# Altium schematic sheet WiFi_Portable_Sensor_Board_C0_MCU-PWR-UI_20180525.SchDoc (continued)
## Library data of the parts (continued)
TP0 = MISC0025: Manufacturer 1: Keystone; Manufacturer Part Number 1: 5011; Database Table Name: Connector; Description_: CON TP LOOP Black TH; Dev Tools Status: Production; Owner: Tad Ahlvers; Populated: YES; Category: Connector; Component Kind: Standard; ComponentLink1Description: SVN Datasheet; ComponentLink1URL: https://chn-vm-apps.microchip.com/mchprepo/parts/Datasheets/M60-2p60.pdf; ComponentLink2Description: Local Datasheet; ComponentLink3Description: Open Symbol; ComponentLink4Description: Open Footprint; ComponentLink5Description: Open Footprint 2; ComponentLink6Description: Open Footprint 3; ComponentLink7Description: Open Footprint 4; Description: Test Point; Library Name: MCHP-CDB.DbLib; MCHP-ID: MISC0025; Quantity Override: 1; Revision: 0; Status: MCL Design; Supplier 1: Digi-Key; Supplier Part Number 1: 36-5011-ND; Symbol: Test Point; Type: Test Point; ComponentLink2URL: C:\ALTIUM_WORK\00001_MCHP-CDB\Datasheets\M60-2p60.pdf; ComponentLink3URL: C:\ALTIUM_WORK\00001_MCHP-CDB\Library\LibraryRefs\Test Point.SchLib; ComponentLink4URL: C:\ALTIUM_WORK\00001_MCHP-CDB\Library\Footprints\CON_TP_TH_V_BLK_KEC_5011.PcbLib
U1 = MIC3182: MCP738XX; Manufacturer 1: Microchip; AutomaticRecharge: Yes; Database Table Name: MCHP-Analog; Description_: MCHP ANALOG BATTERY CHARGER MCP73833-NVI/UN MSOP-10; Dev Tools Status: Production; ForceMinOrderQtyMult: True; IoutMax: 1200; LeadContent: Pb-FREE; LeadCount: 10; MAPS Description: 1A Advanced Single Cell, Fully Integrated Li-Ion/Polymer Charge Management Contr; MinOrderQty: 50; MPC: DECJ2TE3XANV; NumberofLiIonCells: 1; OperatingCurrentTyp: 2; PackageWidth: 3x3mm; PackingMedia: T/R; PassTransistor: INT; ReverseDischargeCurrentTyp: 0.200000002980232; Stage: REL; tblDeviceFamilyID: 1704; TempRange: -40C to +85C; UVLO: 3.5; VccRangeMax: 6; VccRangeMin: 3.75; VolRegAccuracy: 0.75; VoltageRegulationTyp: 4.2, 4.35, 4.4, 4.5; Category: MCHP-Analog; ChargeMonitor: Yes; ChargeSafetyTimers: Yes; ChargeTermination: Yes; Component Kind: Standard; ComponentLink1Description: SVN Datasheet; ComponentLink1URL: http://www.microchip.com/wwwproducts/Devices.aspx?product=MCP73833; ComponentLink2Description: Local Datasheet; ComponentLink3Description: Open Symbol; ComponentLink4Description: Open Footprint; ComponentLink5Description: Open Footprint 2; ComponentLink6Description: Open Footprint 3; ComponentLink7Description: Open Footprint 4; IntroductionDate: 2006-09-05; Library Name: MCHP-CDB.DbLib; Manufacturer 2: Microchip; Manufacturer Part Number 2: MCP73833T-FCI/UN; MaxThermalRegulation: Yes; MCHP-ID: MIC3182; PackageType: MSOP; Populated: Yes; PreconditionCharge: Yes; Quantity Override: 1; Revision: 0; ShutdownMode: Yes; Status: MCL Design; StatusOutput: Yes; Supplier 1: Microchip; Supplier 2: Microchip; Supplier Part Number 1: MCP73833T-NVI/UN; Supplier Part Number 2: MCP73833-NVI/UN; SupplyPresent: Yes; Symbol: MCP73833_4 MSOP-10; Technology: Linear; TempMonitor: Yes; Type: Battery Charger; ComponentLink3URL: C:\ALTIUM_WORK\00001_MCHP-CDB\Library\LibraryRefs\MCP73833_4 MSOP-10.SchLib; ComponentLink4URL: C:\ALTIUM_WORK\00001_MCHP-CDB\Library\Footprints\MSOP-10.PcbLib
U2 = MIC7215: Manufacturer 1: Microchip Technology; Manufacturer Part Number 1: MIC5317-3.3YD5-T5; Database Table Name: MCHP-Analog; Description_: MCHP ANALOG LDO 3.3V MIC5317-3.3YD5 TSOT23-5; Dev Tools Status: Design; ForceMinOrderQtyMult: True; LeadContent: Pb-FREE; LeadCount: 5; MAPS Description: Single, High Performance 150mA LDO; MinOrderQty: 500; MPC: 22660YD5AA15; Owner: Gabor Bihari; PackingMedia: T/R; Populated: YES; Stage: REL; tblDeviceFamilyID: 7461; TempRange: -40C to +125C; Category: MCHP-Analog; Component Kind: Standard; ComponentLink1Description: SVN Datasheet; ComponentLink1URL: http://www.microchip.com/wwwproducts/Devices.aspx?product=MIC5317; ComponentLink2Description: Local Datasheet; ComponentLink3Description: Open Symbol; ComponentLink4Description: Open Footprint; ComponentLink5Description: Open Footprint 2; ComponentLink6Description: Open Footprint 3; ComponentLink7Description: Open Footprint 4; IntroductionDate: 2015-10-18; Library Name: MCHP-CDB.DbLib; MCHP-ID: MIC7215; PackageType: TSOT; PackageWidth: -; Quantity Override: 1; Revision: A; Status: MCL Design; Supplier 1: Digi-Key; Supplier Part Number 1: 1611-MIC5317-3.3YD5-T5CT-ND; Symbol: MIC5317 FIX TSOT-23-5; Type: LDO; ComponentLink2URL: C:\ALTIUM_WORK\00001_MCHP-CDB\Datasheets\MIC5317.pdf; ComponentLink3URL: C:\ALTIUM_WORK\00001_MCHP-CDB\Library\LibraryRefs\MIC5317 FIX TSOT-23-5.SchLib; ComponentLink4URL: C:\ALTIUM_WORK\00001_MCHP-CDB\Library\Footprints\TSOT-23-5.PcbLib
U3 = MIC7211: Manufacturer 1: Microchip Technology; Manufacturer Part Number 1: ATSAML21G18B-MUT; ADCBit: 12; ADC Channels: 14; CAN: 0; CapTouchCH: 96; Comparators: 2; Database Table Name: MCHP-Microcontroller; Description_: MCHP MCU 32-BIT 48MHz 256kB 32kB ATSAML21G18B-MU QFN-48; Dev Tools Status: Design; DigitalTimerQty_8bit: 5; ForceMinOrderQtyMult: True; I2C: 6; Input Capture: 8; IOPins: 37; LeadContent: Pb-FREE; LeadCount: 48; MAPS Description: 48QFN 85C, GREEN,1.6-3.6V,48MHz; MinOrderQty: 4000; MPC: 661A4TT3BC07; OscillatorMHz: 32Khz ULP, 16Mhz; Owner: Gabor Bihari; PackageWidth: 7x7x1mm; PackingMedia: T/R; Populated: YES; Program Memory KBytes: 256; Program Memory KWords: 64; PWM Channels: 20; Ram: 40960; Stage: REL; TempRange: -40C to +85C; USBChannels: 1; Category: MCHP-Microcontroller; Component Kind: Standard; ComponentLink1Description: SVN Datasheet; ComponentLink1URL: http://www.microchip.com/wwwproducts/Devices.aspx?product=ATSAML21G18B; ComponentLink2Description: Local Datasheet; ComponentLink3Description: Open Symbol; ComponentLink4Description: Open Footprint; ComponentLink5Description: Open Footprint 2; ComponentLink6Description: Open Footprint 3; ComponentLink7Description: Open Footprint 4; DAChannels: 2; DigitalTimerQty_16bit: 5; DigitalTimerQty_32bit: 2; EEPROM: 0; Family: SAM L; IntroductionDate: 2016-12-22; Library Name: MCHP-CDB.DbLib; MaxSpeed: 48; MCHP-ID: MIC7211; mtrlcntrlpwmchannels: 0; PackageType: VQFN; QEI Channels: 0; Quantity Override: 1; Revision: A; SegmentLCD: 0; SMPS PWM Channels: 0; SPI: 6; Status: MCL Design; Supplier 1: Digi-Key; Supplier Part Number 1: 1611-ATSAML21G18B-MUTCT-ND; Symbol: ATSAML21G18 QFN-48; Type: 32-Bit; USART: 6; ComponentLink2URL: C:\ALTIUM_WORK\00001_MCHP-CDB\Datasheets\60001477A.pdf; ComponentLink3URL: C:\ALTIUM_WORK\00001_MCHP-CDB\Library\LibraryRefs\ATSAML21G18 QFN-48.SchLib; ComponentLink4URL: C:\ALTIUM_WORK\00001_MCHP-CDB\Library\Footprints\QFN-48_7x7_EP5.1x5.1.PcbLib
X1 = OSC1038: Crystal; Manufacturer 1: Seiko; Manufacturer Part Number 1: SC32S-12.5PF20PPM; Database Table Name: Crystal-Oscillator; Description_: CRYSTAL 32.768kHz 12.5pF SMD ABS07; Dev Tools Status: Production; Load Capacitance: 12.5pF; Owner: Tad Ahlvers; Populated: YES; Tolerance: 20ppm; Category: Crystal/Oscillator; Component Kind: Standard; ComponentLink1Description: SVN Datasheet; ComponentLink1URL: https://chn-vm-apps.microchip.com/mchprepo/parts/Datasheets/ABS07.pdf; ComponentLink2Description: Local Datasheet; ComponentLink3Description: Open Symbol; ComponentLink4Description: Open Footprint; ComponentLink5Description: Open Footprint 2; ComponentLink6Description: Open Footprint 3; ComponentLink7Description: Open Footprint 4; Library Name: MCHP-CDB.DbLib; Manufacturer 2: Abracon; Manufacturer 3: JWT; Manufacturer Part Number 2: ABS07-32.768KHZ-T; Manufacturer Part Number 3: SMD3215-32.768KHZ-1-T;32.768KHz; MCHP-ID: OSC1038; Operating Temperature: -40C to +85C; Package: SMD_ABS07; Quantity Override: 1; Revision: 1; Status: MCL Design; Supplier 1: Digi-Key; Supplier 2: Digi-Key; Supplier 3: Raynen; Supplier Part Number 1: 728-1073-1-ND; Supplier Part Number 2: 535-9542-1-ND; Supplier Part Number 3: JWT SMD3215-32.768KHZ-1-T;32.768KHz; Symbol: Crystal 2 Pins; Type: Crystal; ComponentLink2URL: C:\ALTIUM_WORK\00001_MCHP-CDB\Datasheets\ABS07.pdf; ComponentLink3URL: C:\ALTIUM_WORK\00001_MCHP-CDB\Library\LibraryRefs\Crystal 2 Pins.SchLib; ComponentLink4URL: C:\ALTIUM_WORK\00001_MCHP-CDB\Library\Footprints\CRYSTAL_ABS07.PcbLib
BAT1 = Battery 3 Pin NTC: Component Kind: Standard; Library Name: WiFi_Portable_Sensor_Board_A0.SchLib
